# Altium schematic sheet power_display.SchDoc
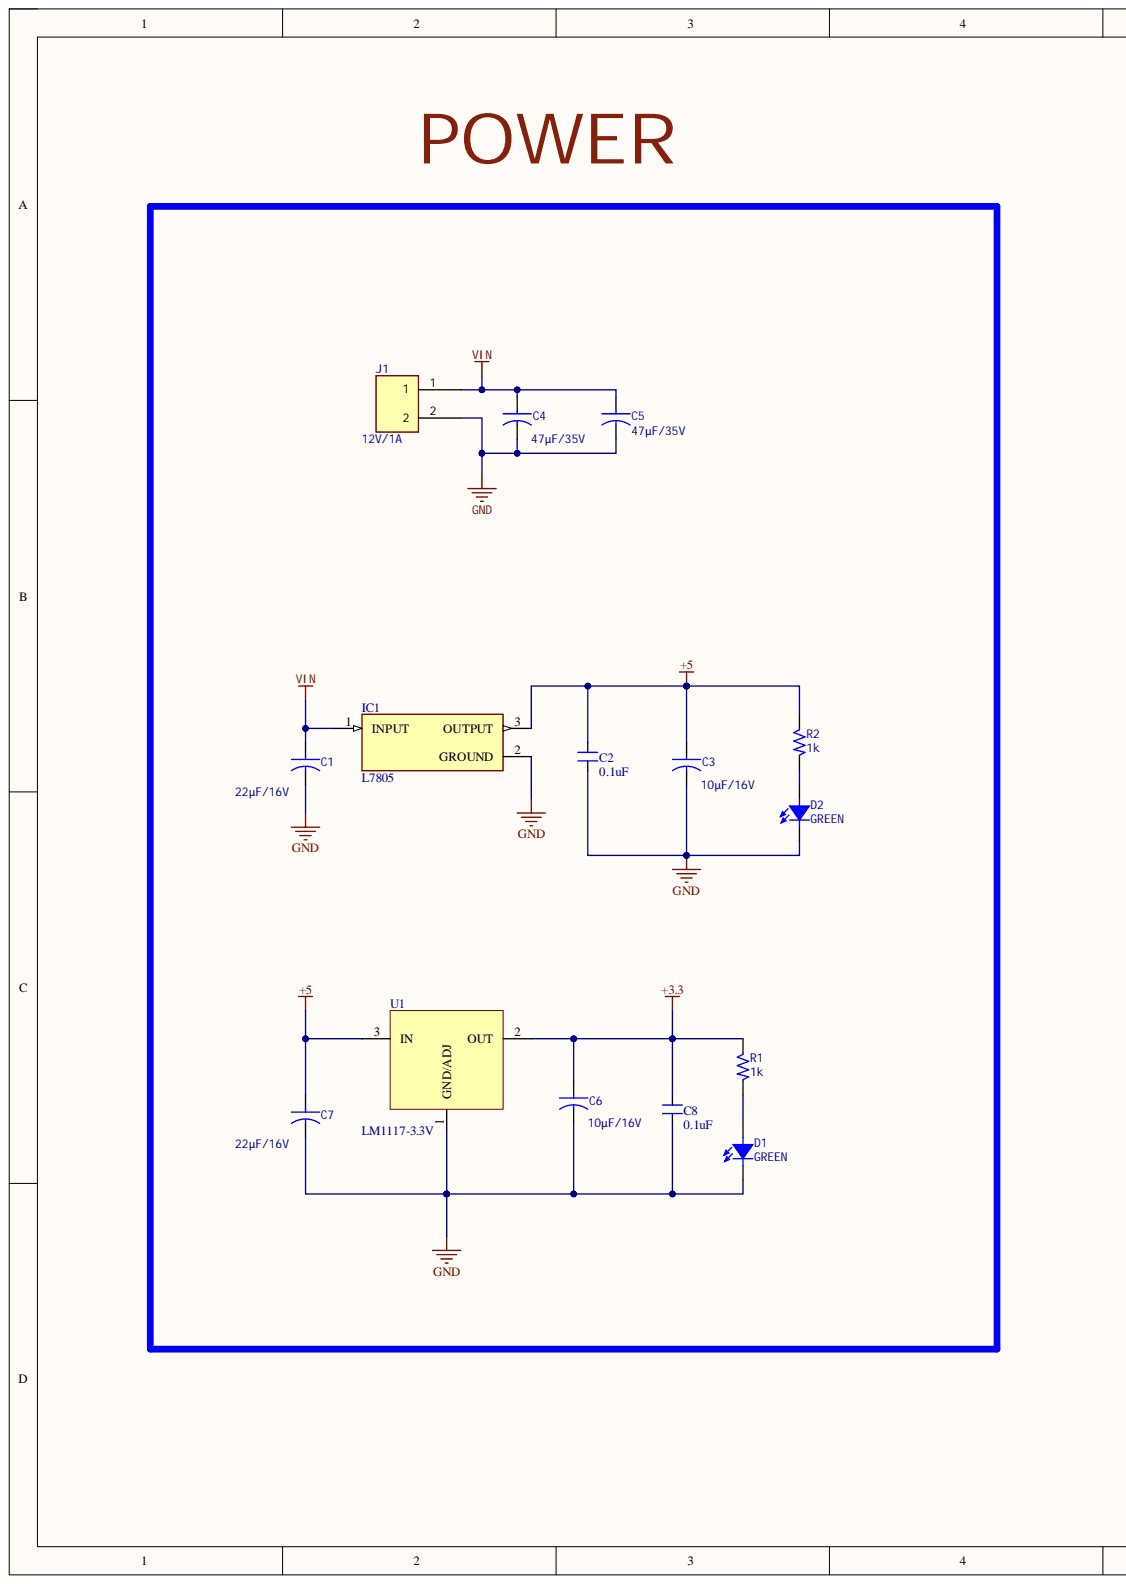
[diagram p0: power_display.SchDoc, left]
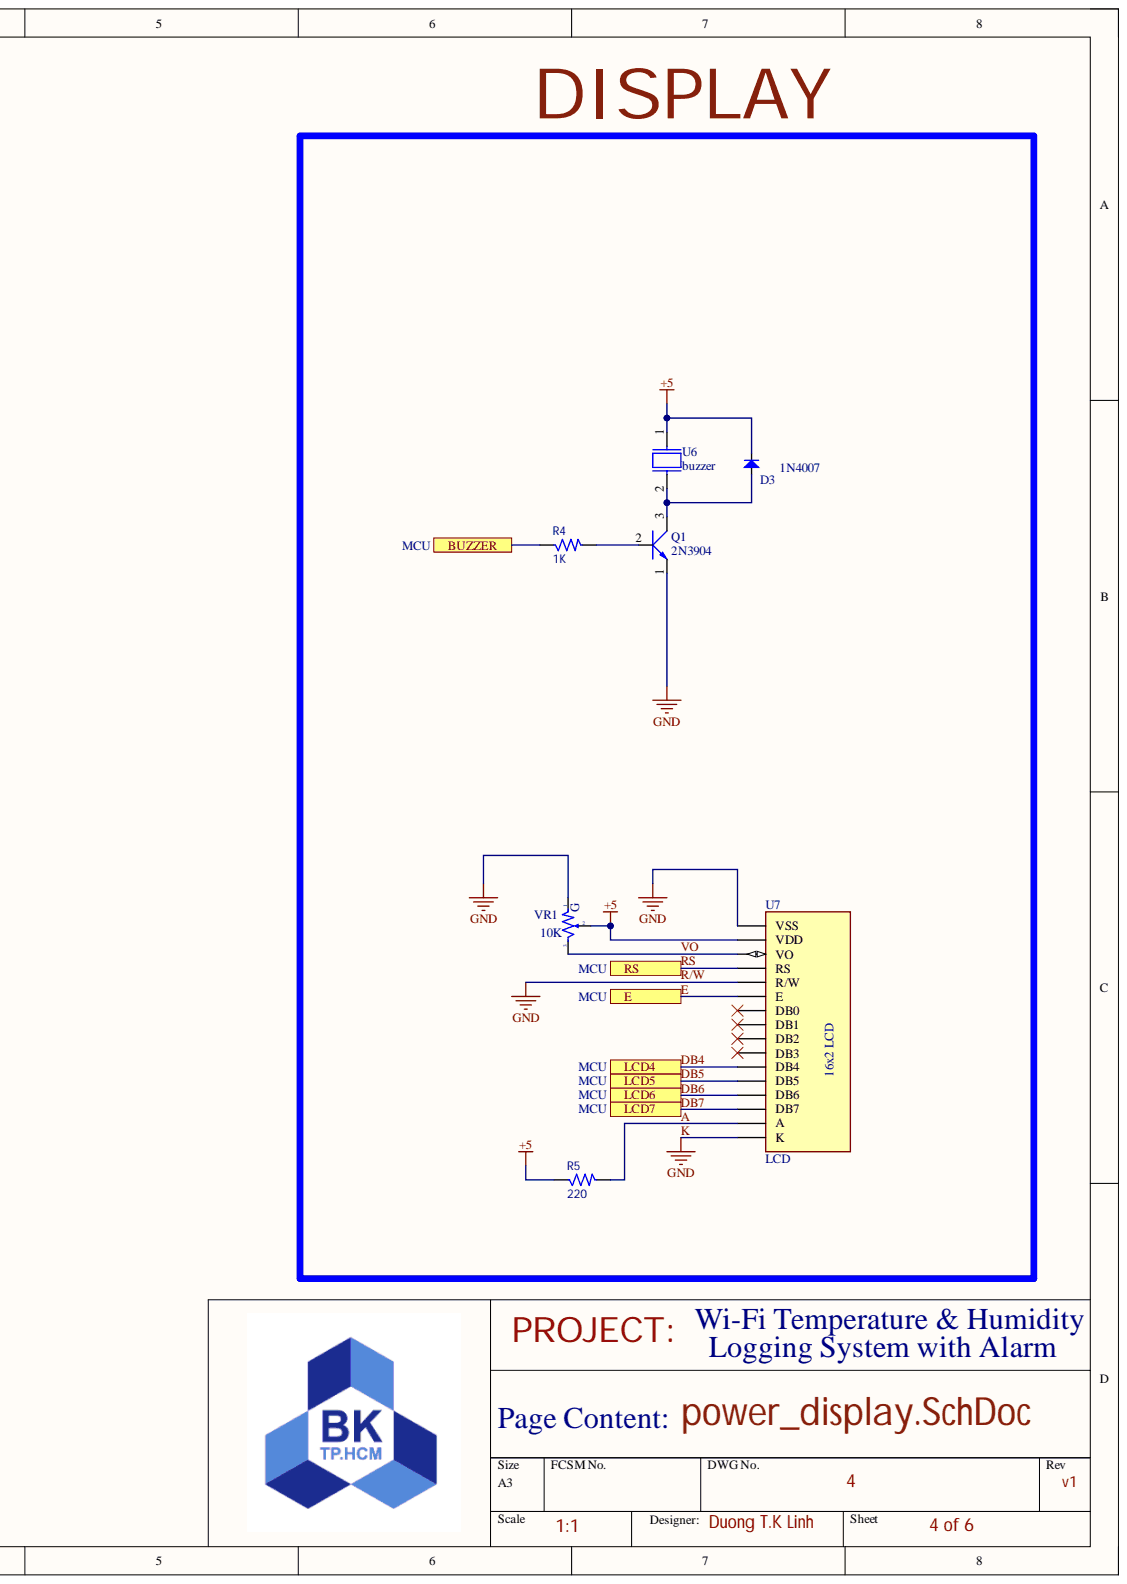
[diagram p1: power_display.SchDoc, right]

## Parts
C1  22µF/16V  [EEH-ZA1V470P]
C2  0.1uF  [CN2]
C3  10µF/16V  [EEH-ZA1V470P]
C4  47µF/35V  [EEH-ZA1V470P]
C5  47µF/35V  [EEH-ZA1V470P]
C6  10µF/16V  [EEH-ZA1V470P]
C7  22µF/16V  [EEH-ZA1V470P]
C8  0.1uF  [CN2]
D1  GREEN  [LED 0603]
D2  GREEN  [LED 0603]
D3  Diode  [DIO-1A]  (value not drawn)
IC1  L7805  [L7805]
J1  12V/1A  [VI0221520000G]
Q1  2N3904  [TO92-123]
R1  1k  [RESISTOR_0603]
R2  1k  [RESISTOR_0603]
R4  1K  [RESISTOR_0603]
R5  220  [RESISTOR_0603]
U1  LM1117-3.3V  [TO220-123]
U6  buzzer  [buzzer]
U7  LCD  [LCD]
VR1  10K  [VR-S1]

## Ports
BUZZER, E, LCD4, LCD5, LCD6, LCD7, RS

## Nets
+3.3: C6.1 C8.2 R1.1 U1.2
+5: C2.2 C3.1 C7.1 D3.2 IC1.3 R2.1 R5.1 U1.3 U6.1 U7.2(VDD) VR1.2
VIN: C1.1 C4.1 C5.1 IC1.1 J1.1
A: R5.2 U7.15(A)
BUZZER: R4.1 ; port BUZZER
DB4: U7.11(DB4) ; port LCD4
DB5: U7.12(DB5) ; port LCD5
DB6: U7.13(DB6) ; port LCD6
DB7: U7.14(DB7) ; port LCD7
E: U7.6(E) ; port E
K: C1.2 C2.1 C3.2 C4.2 C5.2 C6.2 C7.2 C8.1 D1.2 D2.2 IC1.2 J1.2 Q1.1 U1.1 U7.1(VSS) U7.5(R/W) U7.16(K) VR1.1
RS: U7.4(RS) ; port RS
VO: U7.3(VO) VR1.3
NetD1_1: D1.1 R1.2
NetD2_1: D2.1 R2.2
NetD3_1: D3.1 Q1.3 U6.2
NetQ1_2: Q1.2 R4.2

Not connected: U7 7(DB0) 8(DB1) 9(DB2) 10(DB3)

## Library data of the parts
C1 C3 C6 C7 = ID-4: Database Table Name: Sheet1$; VALUE: 47µF/35V; Component Kind: Standard; Design Item ID: ID-4; Library Name: Database.DbLib; Mfr# #: EEH-ZA1V470P; Signal Integrity: Guessed Model
C2 C8 = CN2: Cap np 104 => 105pF; Component Kind: Standard; Value: 0.1uF; Library Name: Compiled_Devzone.IntLib
C4 C5 = ID-4: Database Table Name: Sheet1$; Component Kind: Standard; Design Item ID: ID-4; Library Name: Database.DbLib; Mfr# #: EEH-ZA1V470P; Signal Integrity: Guessed Model; VALUE: 47µF/35V
D1 D2 = ID-23: Green 569nm LED Indication - Discrete 2.1V 0603 (1608 Metric); Mfr# #: LTST-C190GKT; Database Table Name: Sheet1$; Component Kind: Standard; Design Item ID: ID-23; Library Name: Database.DbLib; Signal Integrity: Guessed Model; Value: GREEN
D3 = Diode: Default Diode; Code - JEDEC: DO-214-AB; Value: 1N5007; Component Kind: Standard; Library Name: Altium Library.IntLib; Published: 8-Jun-2000; Revision: July-2002: Re-released for DXP Platform.; Publisher: Altium Limited
J1 = ID-36: 2 Position Wire to Board Terminal Block Horizontal with Board 0.200" (5.08mm) Through Hole; Database Table Name: Sheet1$; Component Kind: Standard; Design Item ID: ID-36; Library Name: Database.DbLib; Mfr# #: VI0221520000G; Signal Integrity: Guessed Model
Q1 = 2N904: NPN 600mW, ECB; Value: C1815; Component Kind: Standard; Library Name: Altium Library.IntLib
R1 R2 R4 R5 = RES-SMD: Component Kind: Standard; Library Name: ID.SchLib; Signal Integrity: Guessed Model
U1 = LM1117: Low-Dropout Linear Regulator; Component Kind: Standard; Website: http://group3i.net; Creator: Nguyen Ba Bien; Library Name: KhanhBK_Library_4.IntLib
VR1 = VR-S: Var Resistor; Value: Volume 50k; Component Kind: Standard; Library Name: Altium Library.IntLib
IC1 = L7805: Component Kind: Standard; Library Name: Schlib1.SchLib
U6 = buzzer: Component Kind: Standard; Library Name: Schlib1.SchLib
U7 = LCD: Component Kind: Standard; Library Name: Schlib1.SchLib
